# Altium schematic sheet LVDS.SchDoc(LVDS)
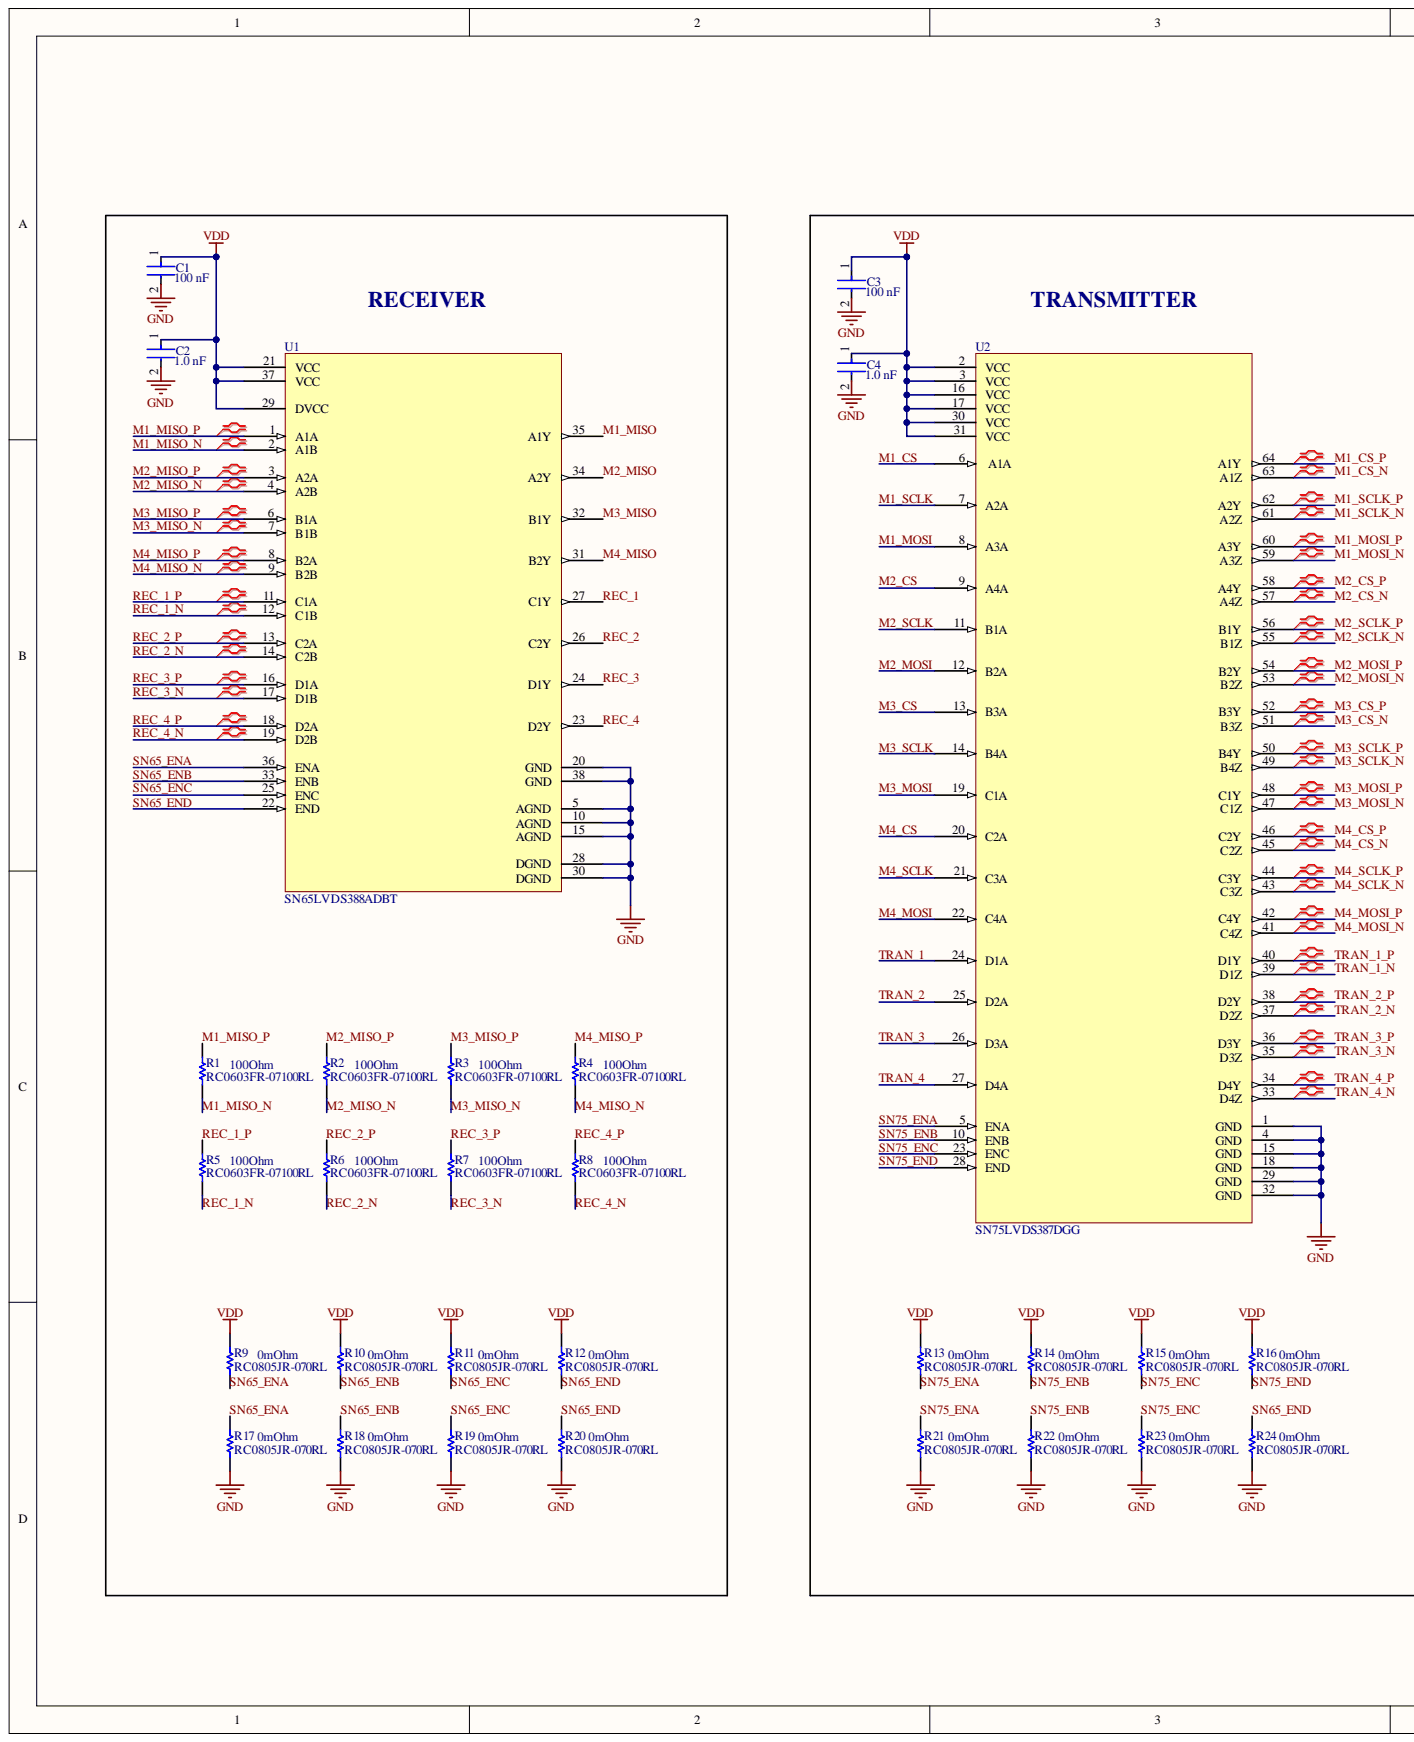
[diagram p0: LVDS.SchDoc, left]
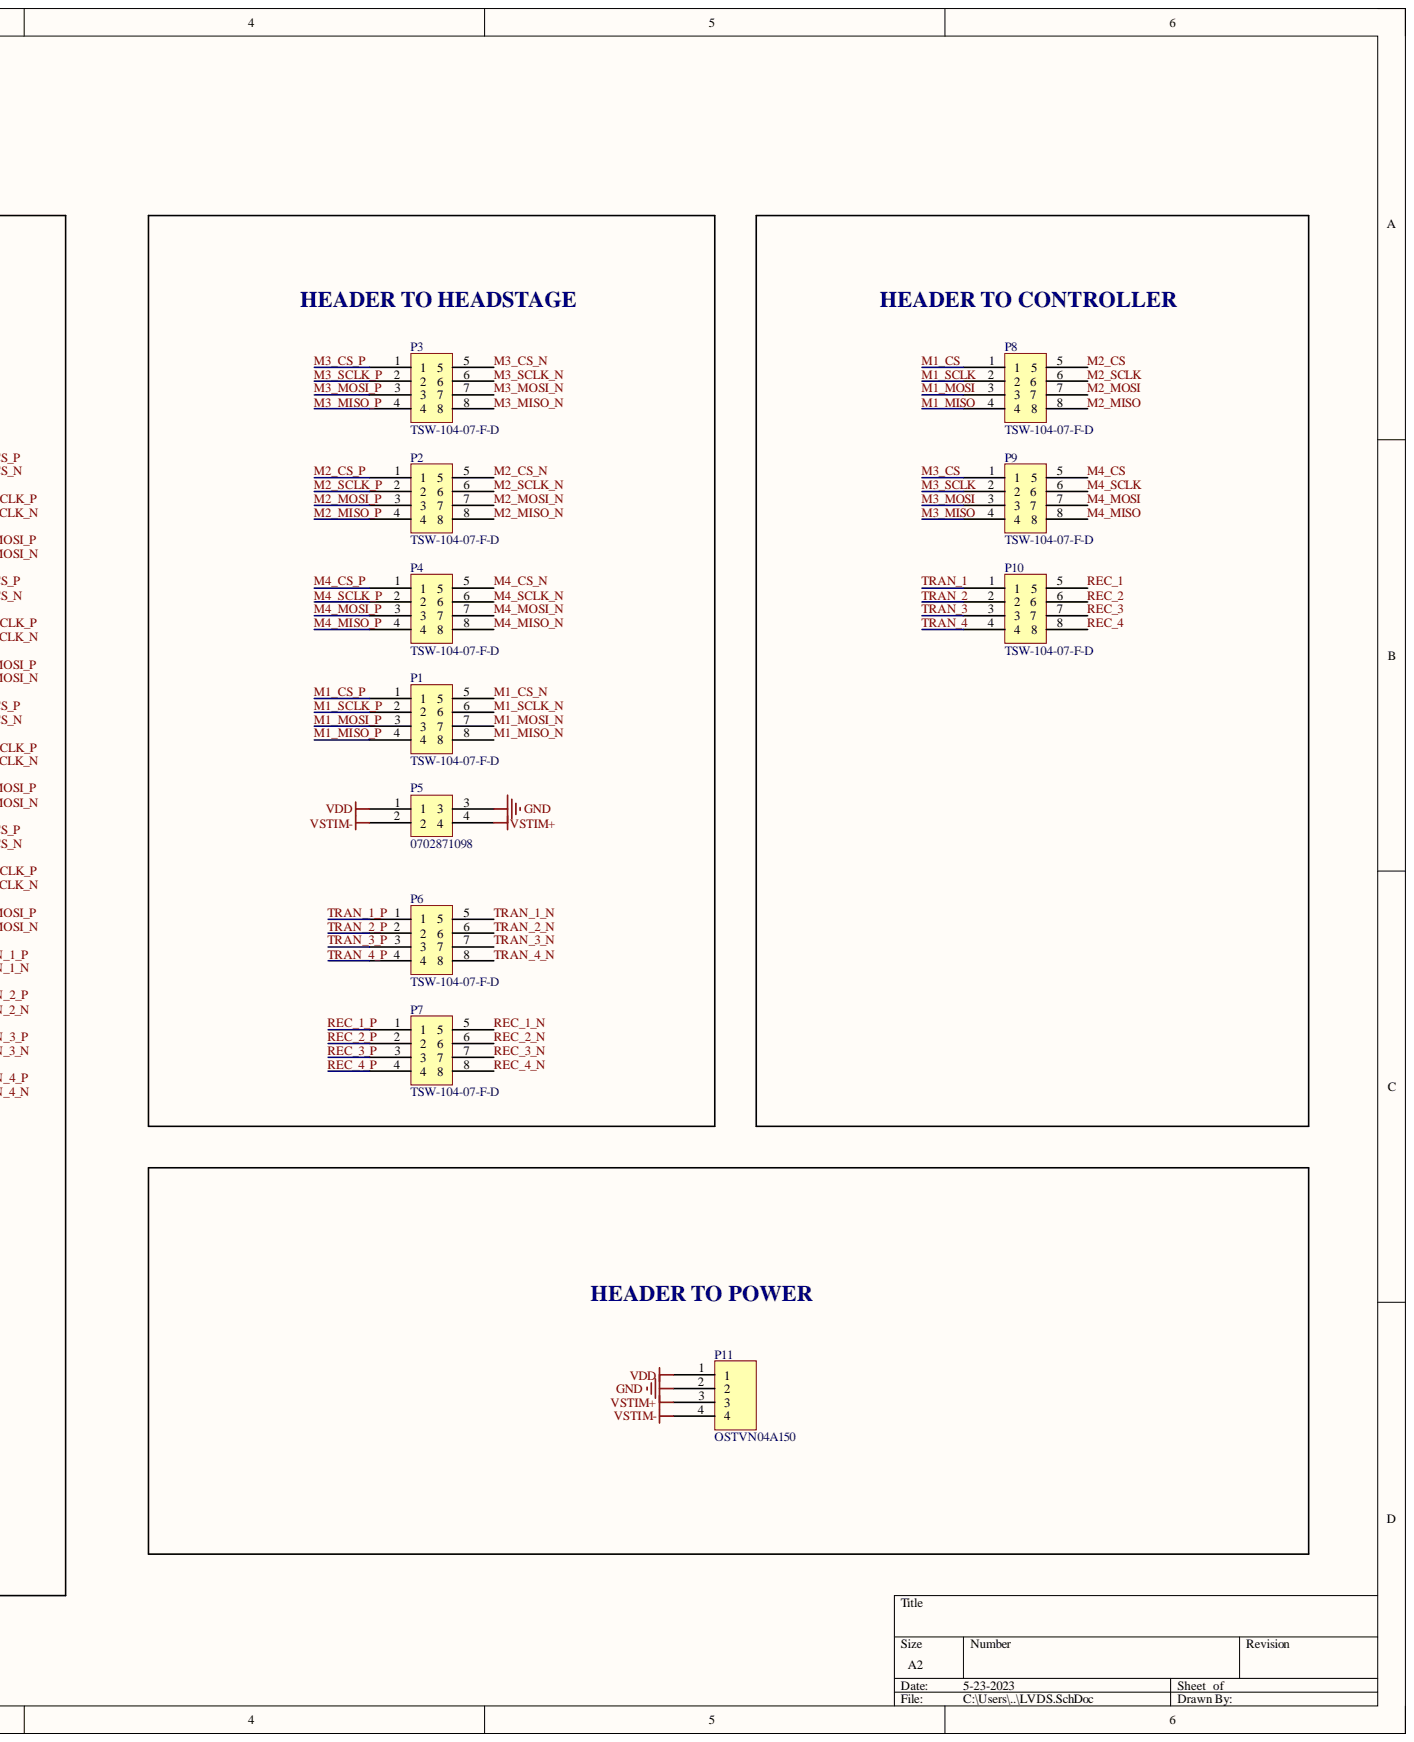
[diagram p1: LVDS.SchDoc, right]

## Parts
C1  value 100 nF  Capacitance: 100 nF  [FP-CC0805-0_85-MFG]  (hidden comment: CC0805MRX7R9BB104)
C2  value 1.0 nF  Capacitance: 1.0 nF  [FP-CC0805-0_85-MFG]  (hidden comment: CC0805KRX7R9BB102)
C3  value 100 nF  Capacitance: 100 nF  [FP-CC0805-0_85-MFG]  (hidden comment: CC0805MRX7R9BB104)
C4  value 1.0 nF  Capacitance: 1.0 nF  [FP-CC0805-0_85-MFG]  (hidden comment: CC0805KRX7R9BB102)
P1  TSW-104-07-F-D  [SAMTEC_TSW-104-07-F-D]
P2  TSW-104-07-F-D  [SAMTEC_TSW-104-07-F-D]
P3  TSW-104-07-F-D  [SAMTEC_TSW-104-07-F-D]
P4  TSW-104-07-F-D  [SAMTEC_TSW-104-07-F-D]
P5  0702871098  [SAMTEC_MTSW-102-09-G-D-630]
P6  TSW-104-07-F-D  [SAMTEC_TSW-104-07-F-D]
P7  TSW-104-07-F-D  [SAMTEC_TSW-104-07-F-D]
P8  TSW-104-07-F-D  [SAMTEC_TSW-104-07-F-D]
P9  TSW-104-07-F-D  [SAMTEC_TSW-104-07-F-D]
P10  TSW-104-07-F-D  [SAMTEC_TSW-104-07-F-D]
P11  OSTVN04A150  [OSTVN04A150]
R1  RC0603FR-07100RL  Resistance: 100Ohm  [RESC1608X55X25ML10T15]
R2  RC0603FR-07100RL  Resistance: 100Ohm  [RESC1608X55X25ML10T15]
R3  RC0603FR-07100RL  Resistance: 100Ohm  [RESC1608X55X25ML10T15]
R4  RC0603FR-07100RL  Resistance: 100Ohm  [RESC1608X55X25ML10T15]
R5  RC0603FR-07100RL  Resistance: 100Ohm  [RESC1608X55X25ML10T15]
R6  RC0603FR-07100RL  Resistance: 100Ohm  [RESC1608X55X25ML10T15]
R7  RC0603FR-07100RL  Resistance: 100Ohm  [RESC1608X55X25ML10T15]
R8  RC0603FR-07100RL  Resistance: 100Ohm  [RESC1608X55X25ML10T15]
R9  RC0805JR-070RL  Resistance: 0mOhm  [RESC2013X60X35ML10T20]
R10  RC0805JR-070RL  Resistance: 0mOhm  [RESC2013X60X35ML10T20]
R11  RC0805JR-070RL  Resistance: 0mOhm  [RESC2013X60X35ML10T20]
R12  RC0805JR-070RL  Resistance: 0mOhm  [RESC2013X60X35ML10T20]
R13  RC0805JR-070RL  Resistance: 0mOhm  [RESC2013X60X35ML10T20]
R14  RC0805JR-070RL  Resistance: 0mOhm  [RESC2013X60X35ML10T20]
R15  RC0805JR-070RL  Resistance: 0mOhm  [RESC2013X60X35ML10T20]
R16  RC0805JR-070RL  Resistance: 0mOhm  [RESC2013X60X35ML10T20]
R17  RC0805JR-070RL  Resistance: 0mOhm  [RESC2013X60X35ML10T20]
R18  RC0805JR-070RL  Resistance: 0mOhm  [RESC2013X60X35ML10T20]
R19  RC0805JR-070RL  Resistance: 0mOhm  [RESC2013X60X35ML10T20]
R20  RC0805JR-070RL  Resistance: 0mOhm  [RESC2013X60X35ML10T20]
R21  RC0805JR-070RL  Resistance: 0mOhm  [RESC2013X60X35ML10T20]
R22  RC0805JR-070RL  Resistance: 0mOhm  [RESC2013X60X35ML10T20]
R23  RC0805JR-070RL  Resistance: 0mOhm  [RESC2013X60X35ML10T20]
R24  RC0805JR-070RL  Resistance: 0mOhm  [RESC2013X60X35ML10T20]
U1  SN65LVDS388ADBT  [DBT0038A_N]
U2  SN75LVDS387DGG  [DGG64]

## Nets
GND: C1.2 C2.2 C3.2 C4.2 P5.3 P11.2 R17.1 R18.1 R19.1 R20.1 R21.1 R22.1 R23.1 R24.1 U1.5(AGND) U1.10(AGND) U1.15(AGND) U1.20(GND) U1.28(DGND) U1.30(DGND) U1.38(GND) U2.1(GND) U2.4(GND) U2.15(GND) U2.18(GND) U2.29(GND) U2.32(GND)
VDD: C1.1 C2.1 C3.1 C4.1 P5.1 P11.1 R9.2 R10.2 R11.2 R12.2 R13.2 R14.2 R15.2 R16.2 U1.21(VCC) U1.29(DVCC) U1.37(VCC) U2.2(VCC) U2.3(VCC) U2.16(VCC) U2.17(VCC) U2.30(VCC) U2.31(VCC)
VSTIM+: P5.4 P11.3
VSTIM-: P5.2 P11.4
M1_CS: P8.1 U2.6(A1A)
M1_CS_N: P1.5 U2.63(A1Z)
M1_CS_P: P1.1 U2.64(A1Y)
M1_MISO: P8.4 U1.35(A1Y)
M1_MISO_N: P1.8 R1.1 U1.2(A1B)
M1_MISO_P: P1.4 R1.2 U1.1(A1A)
M1_MOSI: P8.3 U2.8(A3A)
M1_MOSI_N: P1.7 U2.59(A3Z)
M1_MOSI_P: P1.3 U2.60(A3Y)
M1_SCLK: P8.2 U2.7(A2A)
M1_SCLK_N: P1.6 U2.61(A2Z)
M1_SCLK_P: P1.2 U2.62(A2Y)
M2_CS: P8.5 U2.9(A4A)
M2_CS_N: P2.5 U2.57(A4Z)
M2_CS_P: P2.1 U2.58(A4Y)
M2_MISO: P8.8 U1.34(A2Y)
M2_MISO_N: P2.8 R2.1 U1.4(A2B)
M2_MISO_P: P2.4 R2.2 U1.3(A2A)
M2_MOSI: P8.7 U2.12(B2A)
M2_MOSI_N: P2.7 U2.53(B2Z)
M2_MOSI_P: P2.3 U2.54(B2Y)
M2_SCLK: P8.6 U2.11(B1A)
M2_SCLK_N: P2.6 U2.55(B1Z)
M2_SCLK_P: P2.2 U2.56(B1Y)
M3_CS: P9.1 U2.13(B3A)
M3_CS_N: P3.5 U2.51(B3Z)
M3_CS_P: P3.1 U2.52(B3Y)
M3_MISO: P9.4 U1.32(B1Y)
M3_MISO_N: P3.8 R3.1 U1.7(B1B)
M3_MISO_P: P3.4 R3.2 U1.6(B1A)
M3_MOSI: P9.3 U2.19(C1A)
M3_MOSI_N: P3.7 U2.47(C1Z)
M3_MOSI_P: P3.3 U2.48(C1Y)
M3_SCLK: P9.2 U2.14(B4A)
M3_SCLK_N: P3.6 U2.49(B4Z)
M3_SCLK_P: P3.2 U2.50(B4Y)
M4_CS: P9.5 U2.20(C2A)
M4_CS_N: P4.5 U2.45(C2Z)
M4_CS_P: P4.1 U2.46(C2Y)
M4_MISO: P9.8 U1.31(B2Y)
M4_MISO_N: P4.8 R4.1 U1.9(B2B)
M4_MISO_P: P4.4 R4.2 U1.8(B2A)
M4_MOSI: P9.7 U2.22(C4A)
M4_MOSI_N: P4.7 U2.41(C4Z)
M4_MOSI_P: P4.3 U2.42(C4Y)
M4_SCLK: P9.6 U2.21(C3A)
M4_SCLK_N: P4.6 U2.43(C3Z)
M4_SCLK_P: P4.2 U2.44(C3Y)
REC_1: P10.5 U1.27(C1Y)
REC_1_N: P7.5 R5.1 U1.12(C1B)
REC_1_P: P7.1 R5.2 U1.11(C1A)
REC_2: P10.6 U1.26(C2Y)
REC_2_N: P7.6 R6.1 U1.14(C2B)
REC_2_P: P7.2 R6.2 U1.13(C2A)
REC_3: P10.7 U1.24(D1Y)
REC_3_N: P7.7 R7.1 U1.17(D1B)
REC_3_P: P7.3 R7.2 U1.16(D1A)
REC_4: P10.8 U1.23(D2Y)
REC_4_N: P7.8 R8.1 U1.19(D2B)
REC_4_P: P7.4 R8.2 U1.18(D2A)
SN65_ENA: R9.1 R17.2 U1.36(ENA)
SN65_ENB: R10.1 R18.2 U1.33(ENB)
SN65_ENC: R11.1 R19.2 U1.25(ENC)
SN65_END: R12.1 R20.2 R24.2 U1.22(END)
SN75_ENA: R13.1 R21.2 U2.5(ENA)
SN75_ENB: R14.1 R22.2 U2.10(ENB)
SN75_ENC: R15.1 R23.2 U2.23(ENC)
SN75_END: R16.1 U2.28(END)
TRAN_1: P10.1 U2.24(D1A)
TRAN_1_N: P6.5 U2.39(D1Z)
TRAN_1_P: P6.1 U2.40(D1Y)
TRAN_2: P10.2 U2.25(D2A)
TRAN_2_N: P6.6 U2.37(D2Z)
TRAN_2_P: P6.2 U2.38(D2Y)
TRAN_3: P10.3 U2.26(D3A)
TRAN_3_N: P6.7 U2.35(D3Z)
TRAN_3_P: P6.3 U2.36(D3Y)
TRAN_4: P10.4 U2.27(D4A)
TRAN_4_N: P6.8 U2.33(D4Z)
TRAN_4_P: P6.4 U2.34(D4Y)

## Library data of the parts
C1 C3 = CMP-03422-000633-1: CAP CER 0.1UF 50V X7R 0805; Manufacturer: Yageo; Material: Ceramic; Tolerance: 0.2; Automotive: No; Category: Cap; Component Kind: Standard; ComponentLink2Description: Datasheet; ComponentLink2URL: http://www.yageo.com/documents/recent/UPY-GPHC_Y5V_6.3V-to-50V_8.pdf; Device Class L1: Passive Components; Device Class L2: Capacitors; Device Class L3: Ceramic Capacitors; Digikey Description: CAP CER 0.1UF 50V X7R 0805; Footprint Url: http://www.yageo.com/exep/pages/download/literatures/UPY-C_GEN_24.pdf; Height: 0.95mm; Ipc Land Pattern Name: CAPC200125X85; Lead Free: Yes; Library Name: Altium Content Vault; Manufacturer Part Number: CC0805MRX7R9BB104; Mouser Description: Multilayer Ceramic Capacitors MLCC - SMD/SMT 100nF 50V X7R 20%; Octopart: https://octopart.com/cc0805mrx7r9bb104-yageo-30261726; Package: CC0805-0.85; RoHS: TRUE; Temperature: -30°C to +85°C; Temperature Characteristic: X7R; Temperature Range High: +85°C; Temperature Range Low: -30°C; Voltage Rating: 50 V
C2 C4 = CMP-1036-02978-3: CAP CER 1000PF 50V X7R 0805; Manufacturer: Yageo; Material: Ceramic; Tolerance: 0.1; Automotive: No; Category: Cap; Component Kind: Standard; ComponentLink2Description: Datasheet; ComponentLink2URL: http://www.yageo.com/documents/recent/UPY-GPHC_Y5V_6.3V-to-50V_8.pdf; Device Class L1: Passive Components; Device Class L2: Capacitors; Device Class L3: Ceramic Capacitors; Digikey Description: CAP CER 1000PF 50V X7R 0805; Footprint Url: http://www.yageo.com/exep/pages/download/literatures/UPY-C_GEN_24.pdf; Height: 0.95mm; Ipc Land Pattern Name: CAPC200125X85; Lead Free: Yes; Library Name: Altium Content Vault; Manufacturer Part Number: CC0805KRX7R9BB102; Mouser Description: Multilayer Ceramic Capacitors MLCC - SMD/SMT 1.0nF 50V X7R 10%; Octopart: https://octopart.com/cc0805krx7r9bb102-yageo-39467744; Package: CC0805-0.85; RoHS: TRUE; Temperature: -30°C to +85°C; Temperature Characteristic: X7R; Temperature Range High: +85°C; Temperature Range Low: -30°C; Voltage Rating: 50 V
P1-P4 P6-P10 = TSW-104-07-F-D: Board Connector, 8 Contact(s), 2 Row(s), Male, Straight, 0.1 inch Pitch, Solder Terminal, Black Insulator; Manufacturer: Samtec; Additional Feature: E.L.P.; Body/Shell Style: RECEPTACLE; Contact Pattern: RECTANGULAR; Contact Style: SQ PIN-SKT; DIN Conformance: No; Mating Information: MULTIPLE MATING PARTS AVAILABLE; Number Of Connectors: 1; Number of Contacts: 8; Number Of PCB Rows: 2; Option: General Purpose; PCB Contact Row Spacing: 5.08mm; Reference Standard: UL; Reliability: COMMERCIAL; Body Breadth: 0.1inch; Body Depth: 0.1inch; Category: Connectors; Component Kind: Standard; ComponentLink1Description: https://datasheet.ciiva.com:443/pdfs/VipMasterIC/IC/SAMI/SAMI-S-A0003531373/SAMI-S-A0003531373-1.pdf?src-supplier=IHS+Markit; ComponentLink1URL: https://datasheet.ciiva.com:443/pdfs/VipMasterIC/IC/SAMI/SAMI-S-A0003531373/SAMI-S-A0003531373-1.pdf?src-supplier=IHS+Markit; ComponentLink2Description: https://datasheet.ciiva.com/739/tsw-xxx-xx-xxx-x-xx-xxx-mkt-739906.pdf?src-supplier=Digi-Key; ComponentLink2URL: https://datasheet.ciiva.com/739/tsw-xxx-xx-xxx-x-xx-xxx-mkt-739906.pdf?src-supplier=Digi-Key; Connector Type: BOARD CONNECTOR; Contact Finish - Mating: GOLD FLASH OVER NICKEL (50); Contact Finish - Termination: Matte Tin (Sn) - with Nickel (Ni) barrier; Contact Gender: Male; Contact Material: Bronze; Filter Feature: No; Flammability Rating: UL94 V-0; IEC Conformance: No; Insulation Color: Black; Insulation Material: Glass; JESD-609 Code: e3; Manufacturer Part Number: TSW-104-07-F-D; Mating Contact Pitch: 0.1inch; Mating Contact Row Spacing: 0.1inch; MIL Conformance: No; Mixed Contacts: No; Mounting Style: STRAIGHT; Mounting Technology: Board; Number of Rows Loaded: 2; PCB Contact Pattern: RECTANGULAR; Pricing: 1=0.9123, 50=0.706, 100=0.5756 (USD); Reach SVHC Compliant: Yes; RoHS: Yes; RoHS Compliant: Yes; Stock: 1; Supplier: Arrow Electronics; Supplier Part Number: TSW-104-07-F-D; Terminal Length: 0.1inch; Terminal Pitch: 2.54mm; Termination: Solder; Library Name: LVDS.SchLib
P5 = 0702871098: Conn Unshrouded Header HDR 4 POS 2.54mm Solder ST Thru-Hole C-Grid® Bag; Manufacturer: Molex; Category: Uncategorized; Component Kind: Standard; ComponentLink1Description: https://datasheet.ciiva.com:443/pdfs/VipMasterIC/IC/MOLE/MOLE-S-A0008016477/MOLE-S-A0006766117-1.pdf?src-supplier=IHS+Markit; ComponentLink1URL: https://datasheet.ciiva.com:443/pdfs/VipMasterIC/IC/MOLE/MOLE-S-A0008016477/MOLE-S-A0006766117-1.pdf?src-supplier=IHS+Markit; ComponentLink2Description: https://datasheet.ciiva.com/9470/0702871098-pcb-headers-9470347.pdf?src-supplier=Avnet; ComponentLink2URL: https://datasheet.ciiva.com/9470/0702871098-pcb-headers-9470347.pdf?src-supplier=Avnet; ComponentLink3Description: https://datasheet.ciiva.com/2961/702871098-sd-2961361.pdf?src-supplier=Digi-Key; ComponentLink3URL: https://datasheet.ciiva.com/2961/702871098-sd-2961361.pdf?src-supplier=Digi-Key; Manufacturer Part Number: 0702871098; Pricing: 1=0.53, 10=0.448, 50=0.448, 100=0.349, 1000=0.274, 10000=0.221 (USD); Reach SVHC Compliant: Yes; RoHS: Yes; RoHS Compliant: Yes; Stock: 14353; Supplier: Mouser; Supplier Part Number: 538-70287-1098; Library Name: LVDS.SchLib
P11 = OSTVN04A150: Barrier Strip Terminal Block, 6A, 1 Row(s), 1 Deck(s); Manufacturer: On-Shore Technology; Additional Feature: STANDARD: UL 94V-0, THERMOPLASTIC; Fastening Method: SCREW; Number of Decks: 1; Number of Ways: 4; Safety Approval: UL,CUL; Voltage Rating: 125V; Wire/Cable Gauge: 20AWG; Category: Terminal Blocks; Component Kind: Standard; ComponentLink1Description: https://datasheet.ciiva.com:443/pdfs/VipMasterIC/IC/ONST/ONSTS00240/ONSTS00114-1.pdf?src-supplier=IHS+Markit; ComponentLink1URL: https://datasheet.ciiva.com:443/pdfs/VipMasterIC/IC/ONST/ONSTS00240/ONSTS00114-1.pdf?src-supplier=IHS+Markit; ComponentLink2Description: https://datasheet.ciiva.com/823/ostvnxxa150-823966.pdf?src-supplier=Digi-Key; ComponentLink2URL: https://datasheet.ciiva.com/823/ostvnxxa150-823966.pdf?src-supplier=Digi-Key; Current Rating: 6A; Manufacturer Part Number: OSTVN04A150; Mounting Technology: Board; Number of Rows: 1; Pricing: 1=1.39, 10=0.979, 25=0.9128, 50=0.8286, 100=0.8249, 250=0.6966, 500=0.65994, 1000=0.58661, 5000=0.47662 (USD); Reach SVHC Compliant: Yes; RoHS: Yes; RoHS Compliant: Yes; Stock: 3152; Supplier: Digi-Key; Supplier Part Number: ED10563-ND; Terminal and Terminal Block Type: BARRIER STRIP TERMINAL BLOCK; Library Name: LVDS.SchLib
R1-R8 = CMP-2100-03663-1: Chip Resistor, 100 Ohm, +/- 1%, 0.1 W, -55 to 155 degC, 0603 (1608 Metric), RoHS, Tape and Reel; Manufacturer: Yageo; Power Rating: 100mW; Temperature Coefficient: 100ppm/degreesC; Voltage Rating: 50V; Case Code (Imperial): 0603; Case Code (Metric): 1608; Case/Package: 0603; Component Kind: Standard; Composition: ThickFilm; Datasheet URL: http://www.yageo.com/NewPortal/yageodocoutput?fileName=/pdf/R-Chip/PYu-RC_Group_51_RoHS_L_5.pdf; Datasheet Version: Rev. 5, 10/2016; Depth: 0.8mm; Height: 0.45mm; Lead Free: LeadFree; Length: 1.6mm; Library Name: Altium Content Vault; Manufacturer URL: http://www.yageo.com/; Max Operating Temperature: 155degC; Military Standard: Not; Min Operating Temperature: -55degC; Number of Pins: 2; Package Description: 2-Pin Surface Mount Device, Body 1.6 x 0.8 mm; Package Reference: 0603; Packaging: TapeandReel; REACH SVHC: Unknown; RoHSCompliant: true; Termination: SMD/SMT; Tolerance: 1%; Voltage Rating (DC): 50V; Width: 0.8mm
R9-R24 = CMP-2100-03673-1: Chip Resistor, 0 Ohm, Jumper, +/- 5%, 0.125 W, -55 to 155 degC, 0805 (2012 Metric), RoHS, Tape and Reel; Manufacturer: Yageo; Power Rating: 125mW; Temperature Coefficient: 200ppm/degreesC; Voltage Rating: 150V; Case Code (Imperial): 0805; Case Code (Metric): 2012; Case/Package: 0805; Component Kind: Standard; Composition: ThickFilm; Contact Plating: Tin; Datasheet URL: http://www.yageo.com/NewPortal/yageodocoutput?fileName=/pdf/R-Chip/PYu-RC_Group_51_RoHS_L_5.pdf; Datasheet Version: Rev. 5, 10/2016; Depth: 1.25mm; Height: 0.5mm; Lead Free: LeadFree; Length: 2mm; Library Name: Altium Content Vault; Manufacturer URL: http://www.yageo.com/; Max Operating Temperature: 155degC; Military Standard: Not; Min Operating Temperature: -55degC; Number of Pins: 2; Package Description: 2-Pin Surface Mounted Device, Body 2 x 1.25 mm; Package Reference: 0805; Packaging: TapeandReel; Radiation Hardening: No; REACH SVHC: Unknown; RoHSCompliant: true; Termination: SMD/SMT; Tolerance: 5%; Voltage Rating (DC): 150V; Width: 1.25mm
U1 = SN65LVDS388ADBT: Line Receiver, 8 Func, 8 Rcvr, CMOS, PDSO38; Manufacturer: Texas Instruments; Channels: 8; Differential Output: No; Input Characteristics: DIFFERENTIAL; Max Supply Current: 40mA; Max Supply Voltage: 3.6V; Min Supply Voltage: 3V; Nominal Supply Voltage: 3.3V; Output Low Current-Max: 8mA; Package Body Material: Plastic; Qualification Status: Not Qualified; Receive Delay-Max: 4ns; Case/Package: TSSOP; Category: Interface ICs; Component Kind: Standard; ComponentLink1Description: https://www.ti.com:443/lit/ds/symlink/sn65lvds386.pdf?src-supplier=IHS+Markit; ComponentLink1URL: https://www.ti.com:443/lit/ds/symlink/sn65lvds386.pdf?src-supplier=IHS+Markit; ComponentLink2Description: https://datasheet.ciiva.com/1708/sn65lvds386-1708540.pdf?src-supplier=Digi-Key; ComponentLink2URL: https://datasheet.ciiva.com/1708/sn65lvds386-1708540.pdf?src-supplier=Digi-Key; ComponentLink3Description: https://datasheet.ciiva.com/3151/sn65lvds390-3151563.pdf?src-supplier=Avnet; ComponentLink3URL: https://datasheet.ciiva.com/3151/sn65lvds390-3151563.pdf?src-supplier=Avnet; ComponentLink4Description: https://datasheet.ciiva.com/15151/getdatasheetpartid-43449-15151030.pdf?src-supplier=Verical; ComponentLink4URL: https://datasheet.ciiva.com/15151/getdatasheetpartid-43449-15151030.pdf?src-supplier=Verical; ComponentLink5Description: https://datasheet.ciiva.com/1498/1831133-1498284.pdf?src-supplier=Element14; ComponentLink5URL: https://datasheet.ciiva.com/1498/1831133-1498284.pdf?src-supplier=Element14; Height - Seated (Max): 1.2mm; Interface IC Type: LINE RECEIVER; JESD-609 Code: e4; Length: 9.7mm; Library Name: LVDS.SchLib; Manufacturer Part Number: SN65LVDS388ADBT; Max Operating Temperature: 85°C; Min Operating Temperature: -40°C; Moisture Sensitivity Level: 2; Package Shape: Rectangular; Package Style: SMALL OUTLINE, THIN PROFILE, SHRINK PITCHMeter; Peak Reflow Temperature (Cel): 260°C; Pins: 38; Power Supplies: 3.3V; Pricing: 1=6, 10=5.393, 25=5.0984, 100=4.4187, 250=4.19208, 500=3.76156, 1000=3.1724, 2500=3.01378 (USD); Reach SVHC Compliant: Yes; Receiver Number of Bits: 8; RoHS: Yes; RoHS Compliant: Yes; Stock: 22; Supplier: Digi-Key; Supplier Part Number: 296-6926-5-ND; Surface Mount: Yes; Technology: CMOS; Temperature Grade: INDUSTRIAL; Terminal Finish: Nickel; Terminal Form: Gull Wing; Terminal Pitch: 0.5mm; Terminal Position: DUAL; Width: 4.4mm
U2 = SN75LVDS387DGG: Line Driver, 16 Func, 16 Driver, CMOS, PDSO64; Manufacturer: Texas Instruments; Channels: 16; Differential Output: Yes; High Level Input Current-Max: 20uA; Input Characteristics: STANDARD; Max Supply Voltage: 3.6V; Min Supply Voltage: 3V; Nominal Supply Voltage: 3.3V; Out Swing-Min: 247mV; Output Characteristics: 3-STATE; Package Body Material: Plastic; Qualification Status: Not Qualified; Receive Delay-Max: 0ns; Transmit Delay-Max: 2.9ns; Case/Package: TSSOP; Category: Interface ICs; Component Kind: Standard; ComponentLink1Description: https://www.ti.com:443/lit/ds/symlink/sn65lvds387.pdf?src-supplier=IHS+Markit; ComponentLink1URL: https://www.ti.com:443/lit/ds/symlink/sn65lvds387.pdf?src-supplier=IHS+Markit; ComponentLink2Description: https://datasheet.ciiva.com/3151/sn75lvds389-3151600.pdf?src-supplier=Avnet; ComponentLink2URL: https://datasheet.ciiva.com/3151/sn75lvds389-3151600.pdf?src-supplier=Avnet; ComponentLink3Description: https://datasheet.ciiva.com/1709/sn65lvds387-1709399.pdf?src-supplier=Digi-Key; ComponentLink3URL: https://datasheet.ciiva.com/1709/sn65lvds387-1709399.pdf?src-supplier=Digi-Key; ComponentLink4Description: https://datasheet.ciiva.com/1498/668195-1498817.pdf?src-supplier=Element14; ComponentLink4URL: https://datasheet.ciiva.com/1498/668195-1498817.pdf?src-supplier=Element14; Driver Number of Bits: 16; Height - Seated (Max): 1.2mm; Interface IC Type: LINE DRIVER; JESD-609 Code: e4; Length: 17mm; Library Name: LVDS.SchLib; Manufacturer Part Number: SN75LVDS387DGG; Max Operating Temperature: 70°C; Min Operating Temperature: 0°C; Moisture Sensitivity Level: 2; Package Shape: Rectangular; Package Style: SMALL OUTLINE, THIN PROFILE, SHRINK PITCHMeter; Peak Reflow Temperature (Cel): 260°C; Pins: 64; Power Supplies: 3.3V; Pricing: 1=12.08, 10=10.913, 25=10.4056, 100=9.035, 250=8.62892, 500=7.86756, 1000=6.85238 (USD); Reach SVHC Compliant: Yes; RoHS: Yes; RoHS Compliant: Yes; Stock: 664; Supplier: Digi-Key; Supplier Part Number: 296-2367-5-ND; Surface Mount: Yes; Technology: CMOS; Temperature Grade: COMMERCIAL; Terminal Finish: Nickel; Terminal Form: Gull Wing; Terminal Pitch: 0.5mm; Terminal Position: DUAL; Width: 6.1mm
